# Altium schematic sheet CAN.SchDoc
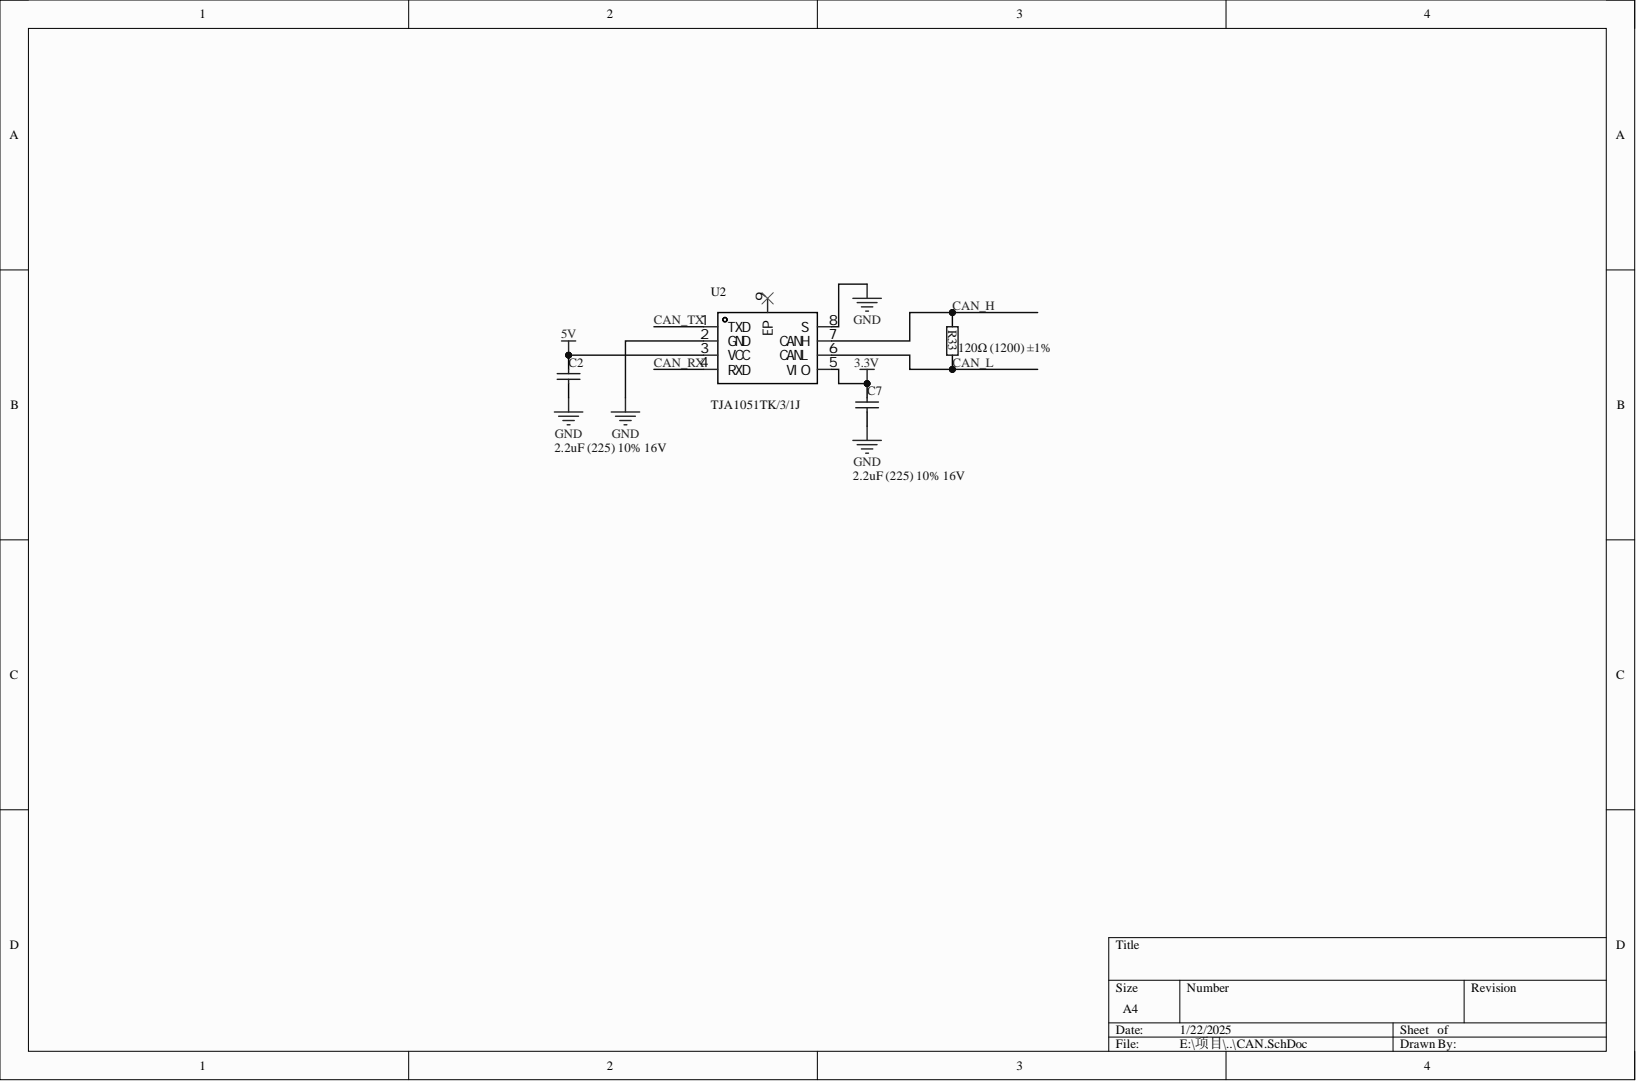
[diagram p0: CAN.SchDoc]

## Parts
C2  2.2uF (225) 10% 16V  [0603_C]
C7  2.2uF (225) 10% 16V  [0603_C]
R33  120Ω (1200) ±1%  [0805_R]
U2  TJA1051TK/3/1J  [HVSON-8_L3.0-W3.0-P0.65-BL-EP]

## Nets
3.3V: C7.1 U2.5(VIO)
5V: C2.1 U2.3(VCC)
GND: C2.2 C7.2 U2.2(GND) U2.8(S)
CAN_H: R33.2 U2.7(CANH)
CAN_L: R33.1 U2.6(CANL)
CAN_RX: U2.4(RXD)
CAN_TX: U2.1(TXD)

Not connected: U2 9(EP)

## Library data of the parts
C2 C7 = 0603 2.2uF (225) 10% 16V: 贴片电容; Component Kind: Standard; ComponentLink1Description: 供应商链接; ComponentLink1URL: http://www.szlcsc.com/product/details_24366.html; Library Name: Cap.SchLib; Package: 0603; Supplier: LC; SuppliersPartNumber: C23630
R33 = 0805 120Ω (1200) 1%: 贴片电阻; Component Kind: Standard; ComponentLink1Description: 供应商链接; ComponentLink1URL: http://www.szlcsc.com/product/details_18125.html; Library Name: RES.SchLib; Package: 0805; Supplier: LC; SuppliersPartNumber: C17437
U2 = TJA1051TK/3/1J: Manufacturer: NXP(恩智浦); Add into BOM: yes; Component Kind: Standard; Convert to PCB: yes; Datasheet: https://atta.szlcsc.com/upload/public/pdf/source/20210128/C2671135_A82E49CDEFC20634F2534E8F261E286A.pdf; Device: TJA1051TK/3/1J; JLCPCB Part Class: Extended Part; LCSC Part Name: TJA1051TK/3/1J; Manufacturer Part: TJA1051TK/3/1J; Name: TJA1051TK/3/1J; PCB3D: HVSON-8_L3.0-W3.0-H0.85-P0.65-BL-EP; Supplier: LCSC; Supplier Footprint: HVSON-8-EP(3x3); Supplier Part: C2875699; Symbol: TJA1051TK/3/1J
